# Altium schematic sheet BLDC Motor Reversal.SchDoc
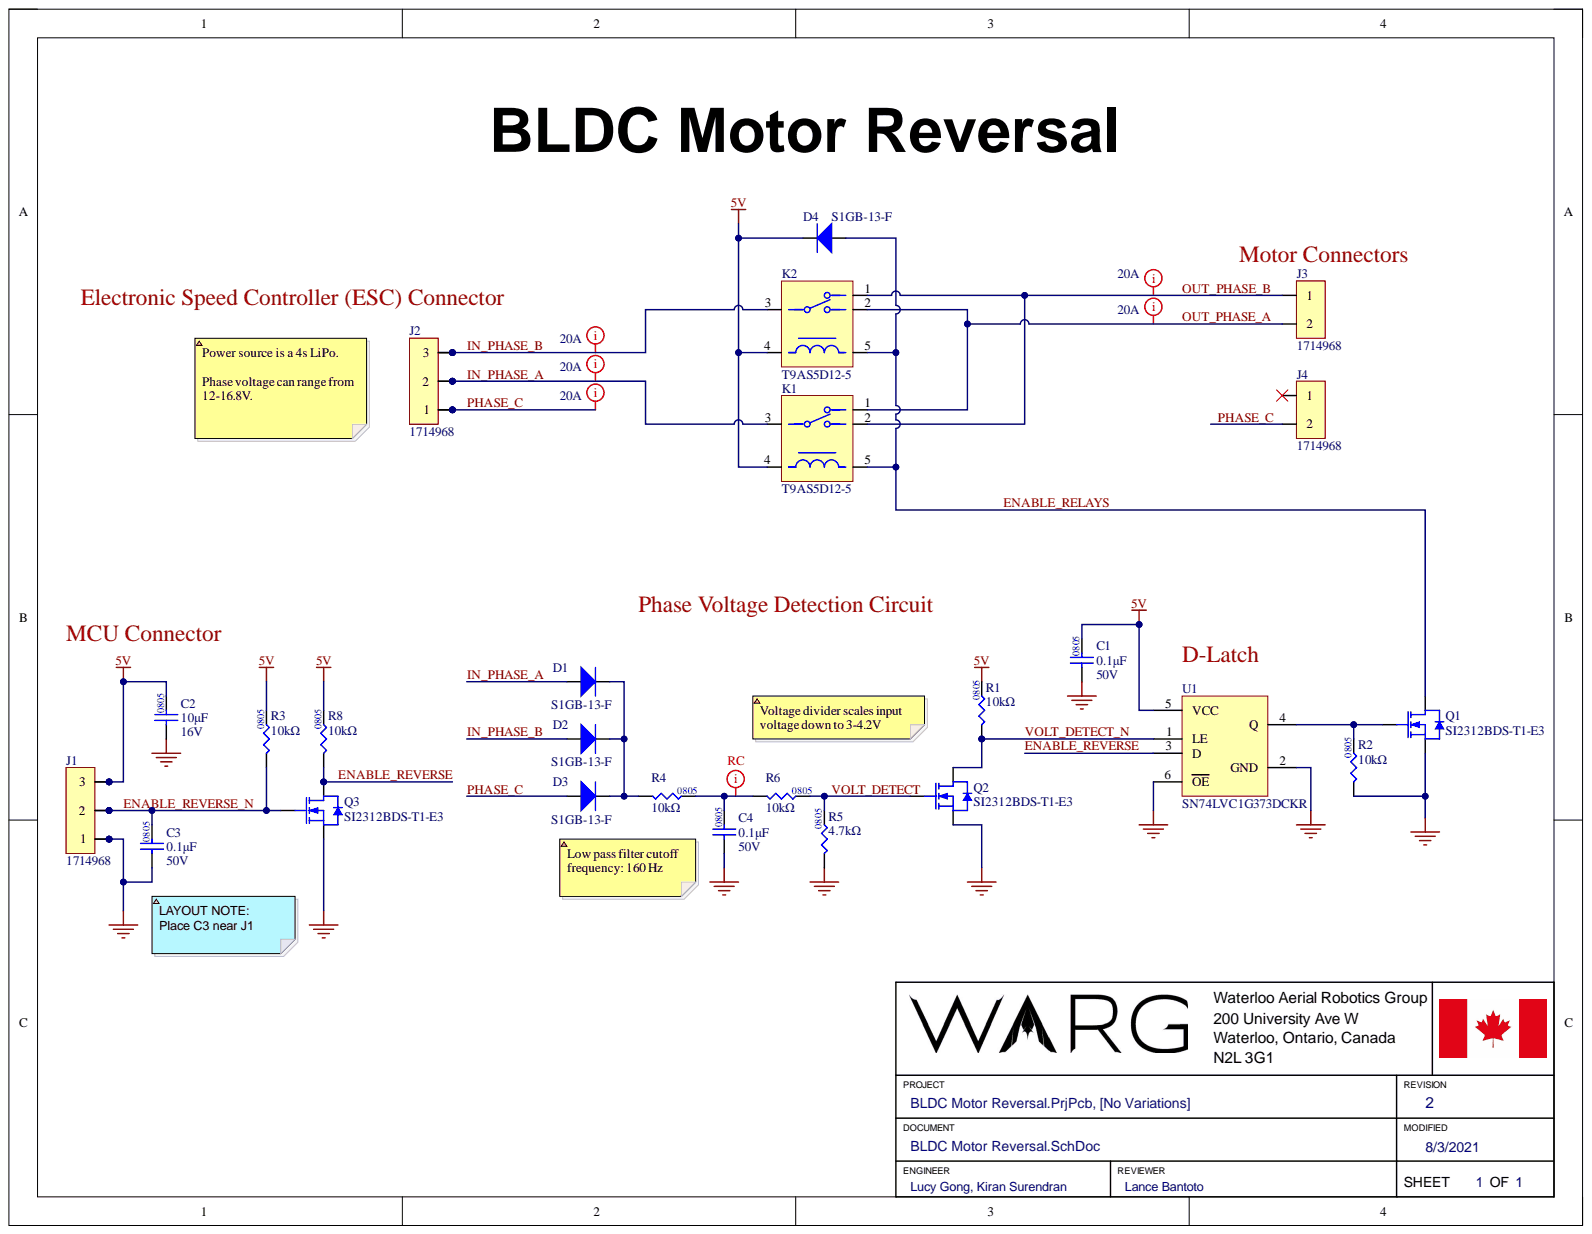
[diagram p0: BLDC Motor Reversal.SchDoc]

## Parts
C1  value 0.1μF  Package: 0805  Voltage Rating: 50V  [C0805C104K5RACTU]  (hidden comment: C0805C104K5RACTU)
C2  value 10μF  Case/Package: 0805  Voltage Rating: 16V  [C0805C106K4PACTU]
C3  value 0.1μF  Package: 0805  Voltage Rating: 50V  [C0805C104K5RACTU]  (hidden comment: C0805C104K5RACTU)
C4  value 0.1μF  Package: 0805  Voltage Rating: 50V  [C0805C104K5RACTU]  (hidden comment: C0805C104K5RACTU)
D1  S1GB-13-F  [S1GB-13-F]
D2  S1GB-13-F  [S1GB-13-F]
D3  S1GB-13-F  [S1GB-13-F]
D4  S1GB-13-F  [S1GB-13-F]
J1  1714968  [1714968]
J2  1714968  [1714968]
J3  Manufacturer Part Number 1: 1714968  [1714955]  (hidden comment: 1714955)
J4  Manufacturer Part Number 1: 1714968  [1714955]  (hidden comment: 1714955)
K1  T9AS5D12-5  [T9AS5D12-5]
K2  T9AS5D12-5  [T9AS5D12-5]
Q1  (no value)  [SI2312BDS-T1-E3]
Q2  SI2312BDS-T1-E3  [SI2312BDS-T1-E3]
Q3  SI2312BDS-T1-E3  [SI2312BDS-T1-E3]
R1  value 10kΩ  Package: 0805  [RC0805JR-0710KL]  (hidden comment: RC0805JR-0710KL)
R2  value 10kΩ  Package: 0805  [RC0805JR-0710KL]  (hidden comment: RC0805JR-0710KL)
R3  value 10kΩ  Package: 0805  [RC0805JR-0710KL]  (hidden comment: RC0805JR-0710KL)
R4  value 10kΩ  Package: 0805  [RC0805JR-0710KL]  (hidden comment: RC0805JR-0710KL)
R5  value 4.7kΩ  Package: 0805  [RC0805JR-0747KL]  (hidden comment: RC0805JR-0747KL)
R6  value 10kΩ  Package: 0805  [RC0805JR-0710KL]  (hidden comment: RC0805JR-0710KL)
R8  value 10kΩ  Package: 0805  [RC0805JR-0710KL]  (hidden comment: RC0805JR-0710KL)
U1  SN74LVC1G373DCKR  [DCK0006A_N]

## Nets
5V: C1.2 C2.2 D4.1 J1.3 K1.4 K2.4 R1.2 R3.2 R8.2 U1.5(VCC)
GND: C1.1 C2.1 C3.1 C4.1 J1.1 Q1.2 Q2.2 Q3.2 R2.1 R5.1 U1.2(GND) U1.6(OE)
ENABLE_RELAYS: D4.2 K1.5 K2.5 Q1.3
ENABLE_REVERSE: Q3.3 R8.1 U1.3(D)
ENABLE_REVERSE_N: C3.2 J1.2 Q3.1 R3.1
IN_PHASE_A: D1.2 J2.2 K1.3
IN_PHASE_B: D2.2 J2.3 K2.3
OUT_PHASE_A: J3.2 K1.1 K2.2
OUT_PHASE_B: J3.1 K1.2 K2.1
PHASE_C: D3.2 J2.1 J4.2
VOLT_DETECT: Q2.1 R5.2 R6.2
VOLT_DETECT_N: Q2.3 R1.1 U1.1(LE)
NetC4_2: C4.2 R4.2 R6.1
NetD1_1: D1.1 D2.1 D3.1 R4.1
NetQ1_1: Q1.1 R2.2 U1.4(Q)

Not connected: J4 1

## Library data of the parts
C1 C3 C4 = C0805C104K5RACTU: CAP CER 0.1UF 50V X7R 0805; Manufacturer 1: KEMET; Component Kind: Standard; Library Name: BLDC_Motor_SchLib.SchLib; Manufacturer Part Number 1: C0805C104K5RACTU; Supplier 1: Digi-Key; Supplier Part Number 1: 399-1170-1-ND
C2 = C0805C106K4PACTU: CAP CER 10UF 16V X5R 0805; Manufacturer 1: KEMET; Component Kind: Standard; Library Name: BLDC_Motor_Lib.SchLib; Manufacturer Part Number 1: C0805C106K4PACTU; Supplier 1: Digi-Key; Supplier Part Number 1: 399-8012-1-ND
D1-D4 = S1GB-13-F: DIODE GEN PURP 400V 1A SMB; Manufacturer 1: Diodes Incorporated; IFSM: 8.0A; VRRM: 325V; Component Kind: Standard; Manufacturer Part Number 1: S1GB-13-F; Supplier 1: Digi-Key; Supplier Part Number 1: S1GB-FDICT-ND
  D1-D3: Library Name: BLDC_Motor_Lib.SchLib
  D4: Library Name: BLDC_Motor_SchLib.SchLib
J1 J2 = 1714968: TERM BLK 3P SIDE ENT 6.35MM PCB; Manufacturer 1: Phoenix Contact; Component Kind: Standard; Library Name: BLDC_Motor_SchLib.SchLib; Manufacturer Part Number 1: 1714968; Supplier 1: Digi-Key; Supplier Part Number 1: 277-1270-ND
J3 J4 = 1714955: TERM BLK 2P SIDE ENT 6.35MM PCB; Manufacturer 1: Phoenix Contact; Current Rating: 30A; Voltage Rating: 300V; Component Kind: Standard; Library Name: BLDC_Motor_SchLib.SchLib; Supplier 1: Digi-Key; Supplier Part Number 1: 277-1269-ND
K1 K2 = T9AS5D12-5: RELAY GEN PURPOSE SPDT 20A 5V; Manufacturer 1: TE Connectivity Potter & Brumfield Relays; Coil Current: 200mA; Contact Voltage Rating (AC): 277V; Current Rating: 30A; Coil Voltage (DC): 5V; Component Kind: Standard; Contact Current Rating: 20A; Library Name: BLDC_Motor_Lib.SchLib; Manufacturer Part Number 1: T9AS5D12-5; Supplier 1: Digi-Key; Supplier Part Number 1: T9AS5D12-5-ND; Voltage Rating (DC): 5V
Q1-Q3 = SI2312BDS-T1-E3: MOSFET N-CH 20V 3.9A SOT23-3; Manufacturer 1: Vishay Siliconix; Component Kind: Standard; Manufacturer Part Number 1: SI2312BDS-T1-E3; Supplier 1: Digi-Key; Supplier Part Number 1: SI2312BDS-T1-E3CT-ND
  Q1: Library Name: BLDC_Motor_Lib.SchLib
  Q2 Q3: Library Name: BLDC_Motor_SchLib.SchLib
R1-R4 R6 R8 = RC0805JR-0710KL: RES SMD 10K OHM 5% 1/8W 0805; Manufacturer 1: Yageo; Component Kind: Standard; Library Name: BLDC_Motor_SchLib.SchLib; Manufacturer Part Number 1: RC0805JR-0710KL; Supplier 1: Digi-Key; Supplier Part Number 1: 311-10KARCT-ND; Tolerance: 5%
R5 = RC0805JR-074K7L: RES SMD 4.7K OHM 5% 1/8W 0805; Manufacturer 1: Yageo; Component Kind: Standard; Library Name: BLDC_Motor_SchLib.SchLib; Manufacturer Part Number 1: RC0805JR-074K7L; Supplier 1: Digi-Key; Supplier Part Number 1: 311-4.7KARTR-ND
U1 = SN74LVC1G373DCKR: IC LTCH D-TYP SGL 3-OUT SC70-6; Manufacturer 1: Texas Instruments; Component Kind: Standard; Library Name: BLDC_Motor_SchLib.SchLib; Manufacturer Part Number 1: SN74LVC1G373DCKR; Supplier 1: Digi-Key; Supplier Part Number 1: 296-18775-1-ND
